# Altium schematic sheet 7-SEGMENT_DISPLAY_4_DIGITS.SchDoc
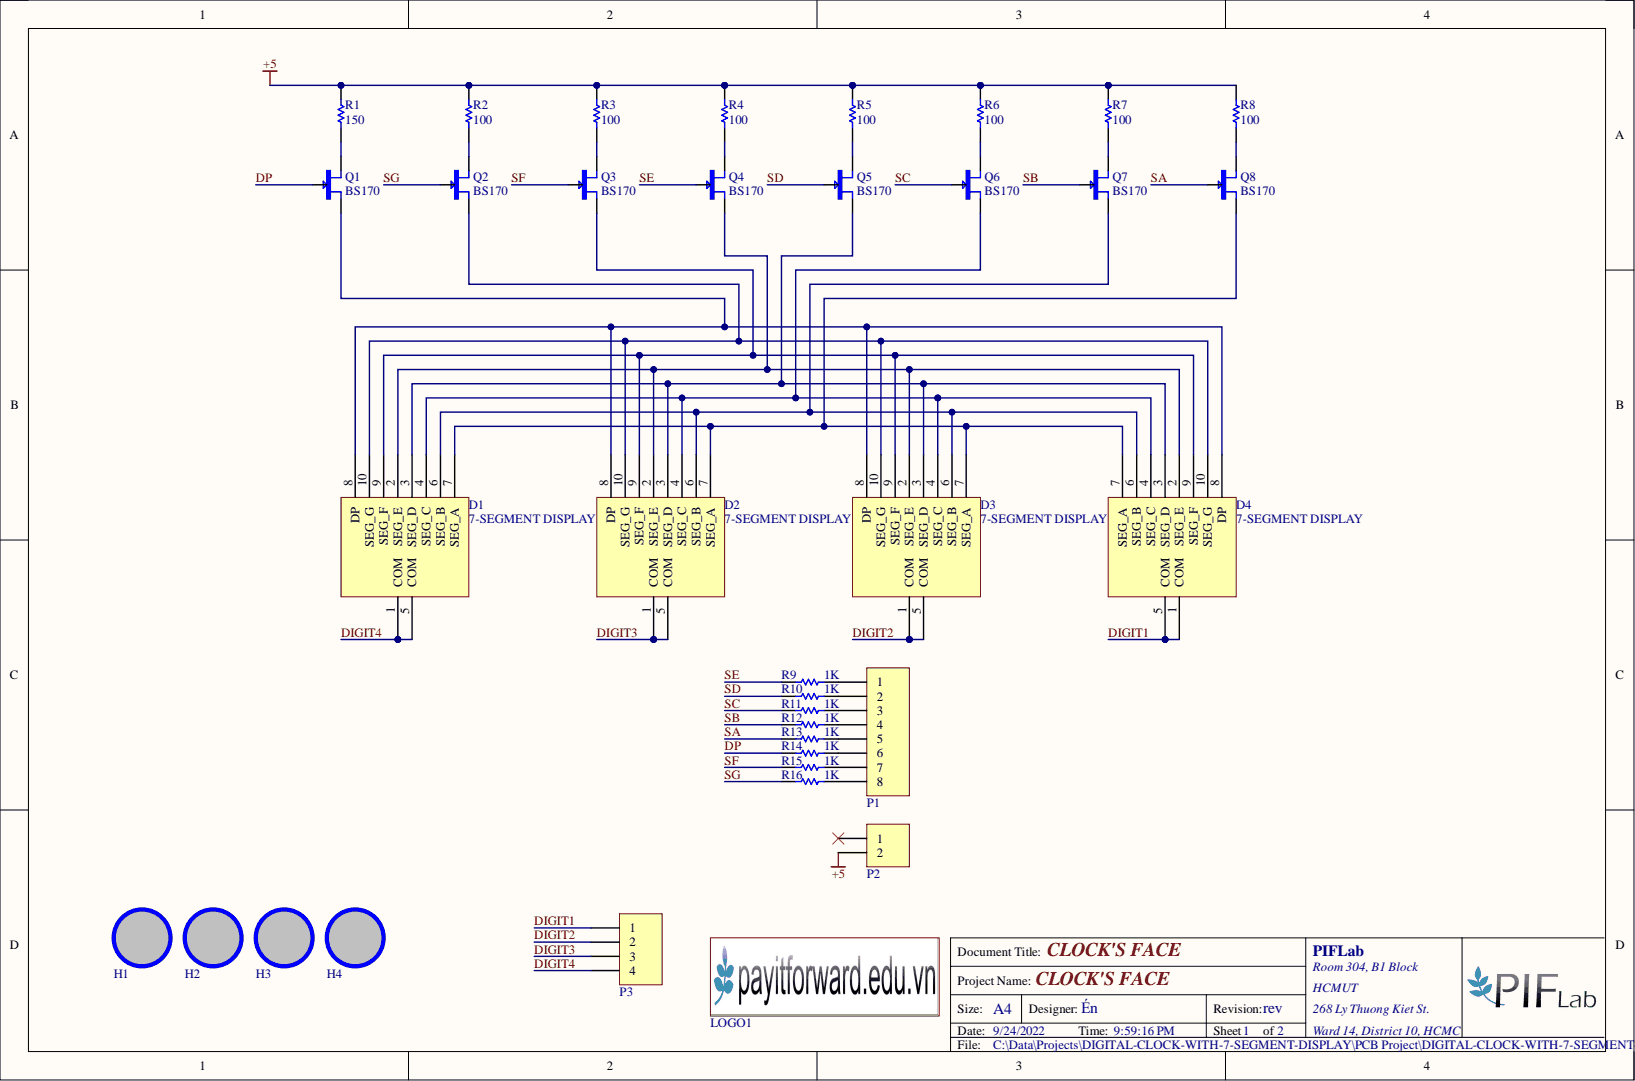
[diagram p0: 7-SEGMENT_DISPLAY_4_DIGITS.SchDoc]

## Parts
D1  7-SEGMENT DISPLAY  [7-SEGMENT]
D2  7-SEGMENT DISPLAY  [7-SEGMENT]
D3  7-SEGMENT DISPLAY  [7-SEGMENT]
D4  7-SEGMENT DISPLAY  [7-SEGMENT]
H1  Hole  [LO KHOAN 3MM]  (value not drawn)
H2  Hole  [LO KHOAN 3MM]  (value not drawn)
H3  Hole  [LO KHOAN 3MM]  (value not drawn)
H4  Hole  [LO KHOAN 3MM]  (value not drawn)
LOGO1  LOGO PIF  [PIF LOGO BIG TOP]  (value not drawn)
P1  Header 8  [JP8]  (value not drawn)
P2  Header 2  [JP2]  (value not drawn)
P3  Header 4  [JP4]  (value not drawn)
Q1  BS170  [BS170]
Q2  BS170  [BS170]
Q3  BS170  [BS170]
Q4  BS170  [BS170]
Q5  BS170  [BS170]
Q6  BS170  [BS170]
Q7  BS170  [BS170]
Q8  BS170  [BS170]
R1  value 150  [RES 1/2W]  (hidden comment: RESISTOR)
R2  value 100  [RES 1/2W]  (hidden comment: RESISTOR)
R3  value 100  [RES 1/2W]  (hidden comment: RESISTOR)
R4  value 100  [RES 1/2W]  (hidden comment: RESISTOR)
R5  value 100  [RES 1/2W]  (hidden comment: RESISTOR)
R6  value 100  [RES 1/2W]  (hidden comment: RESISTOR)
R7  value 100  [RES 1/2W]  (hidden comment: RESISTOR)
R8  value 100  [RES 1/2W]  (hidden comment: RESISTOR)
R9  value 1K  [RES 1/2W]  (hidden comment: RESISTOR)
R10  value 1K  [RES 1/2W]  (hidden comment: RESISTOR)
R11  value 1K  [RES 1/2W]  (hidden comment: RESISTOR)
R12  value 1K  [RES 1/2W]  (hidden comment: RESISTOR)
R13  value 1K  [RES 1/2W]  (hidden comment: RESISTOR)
R14  value 1K  [RES 1/2W]  (hidden comment: RESISTOR)
R15  value 1K  [RES 1/2W]  (hidden comment: RESISTOR)
R16  value 1K  [RES 1/2W]  (hidden comment: RESISTOR)

## Nets
+5: P2.2 R1.2 R2.2 R3.2 R4.2 R5.2 R6.2 R7.2 R8.2
DIGIT1: D4.1(COM) D4.5(COM) P3.1
DIGIT2: D3.1(COM) D3.5(COM) P3.2
DIGIT3: D2.1(COM) D2.5(COM) P3.3
DIGIT4: D1.1(COM) D1.5(COM) P3.4
DP: Q1.3 R14.1
SA: Q8.3 R13.1
SB: Q7.3 R12.1
SC: Q6.3 R11.1
SD: Q5.3 R10.1
SE: Q4.3 R9.1
SF: Q3.3 R15.1
SG: Q2.3 R16.1
NetD1_2: D1.2(SEG_E) D2.2(SEG_E) D3.2(SEG_E) D4.2(SEG_E) Q4.2
NetD1_3: D1.3(SEG_D) D2.3(SEG_D) D3.3(SEG_D) D4.3(SEG_D) Q5.2
NetD1_4: D1.4(SEG_C) D2.4(SEG_C) D3.4(SEG_C) D4.4(SEG_C) Q6.2
NetD1_6: D1.6(SEG_B) D2.6(SEG_B) D3.6(SEG_B) D4.6(SEG_B) Q7.2
NetD1_7: D1.7(SEG_A) D2.7(SEG_A) D3.7(SEG_A) D4.7(SEG_A) Q8.2
NetD1_8: D1.8(DP) D2.8(DP) D3.8(DP) D4.8(DP) Q1.2
NetD1_9: D1.9(SEG_F) D2.9(SEG_F) D3.9(SEG_F) D4.9(SEG_F) Q3.2
NetD1_10: D1.10(SEG_G) D2.10(SEG_G) D3.10(SEG_G) D4.10(SEG_G) Q2.2
NetP1_1: P1.1 R9.2
NetP1_2: P1.2 R10.2
NetP1_3: P1.3 R11.2
NetP1_4: P1.4 R12.2
NetP1_5: P1.5 R13.2
NetP1_6: P1.6 R14.2
NetP1_7: P1.7 R15.2
NetP1_8: P1.8 R16.2
NetQ1_1: Q1.1 R1.1
NetQ2_1: Q2.1 R2.1
NetQ3_1: Q3.1 R3.1
NetQ4_1: Q4.1 R4.1
NetQ5_1: Q5.1 R5.1
NetQ6_1: Q6.1 R6.1
NetQ7_1: Q7.1 R7.1
NetQ8_1: Q8.1 R8.1

Not connected: P2 1

## Library data of the parts
D1-D4 = 7-SEGMENT: Component Kind: Standard; Library Name: Giang's Libs.IntLib
H1-H4 = Hole: Hole 3mm; Component Kind: Standard; Library Name: PIF_Library.IntLib
P1 = Header 8: Header, 8-Pin; Component Kind: Standard; LatestRevisionDate: 17-Jul-2002; LatestRevisionNote: Re-released for DXP Platform.; Library Name: PIF_Library.IntLib; Publisher: Altium Limited
P2 = Header 2: Header, 2-Pin; Component Kind: Standard; LatestRevisionDate: 17-Jul-2002; LatestRevisionNote: Re-released for DXP Platform.; Library Name: PIF_Library.IntLib; Publisher: Altium Limited
P3 = Header 4: Header, 4-Pin; Component Kind: Standard; LatestRevisionDate: 17-Jul-2002; LatestRevisionNote: Re-released for DXP Platform.; Library Name: PIF_Library.IntLib; Publisher: Altium Limited
LOGO1 = LOGO PIF: Component Kind: Standard; Library Name: PIF_Library.IntLib
Q1-Q8 = BS170: Component Kind: Standard; Library Name: Giang's Libs.IntLib
R1-R16 = RESISTOR: Component Kind: Standard; Description: Resistor; Library Name: PIF_Library.IntLib
